# Altium schematic sheet Sheet12.SchDoc(Sheet12)
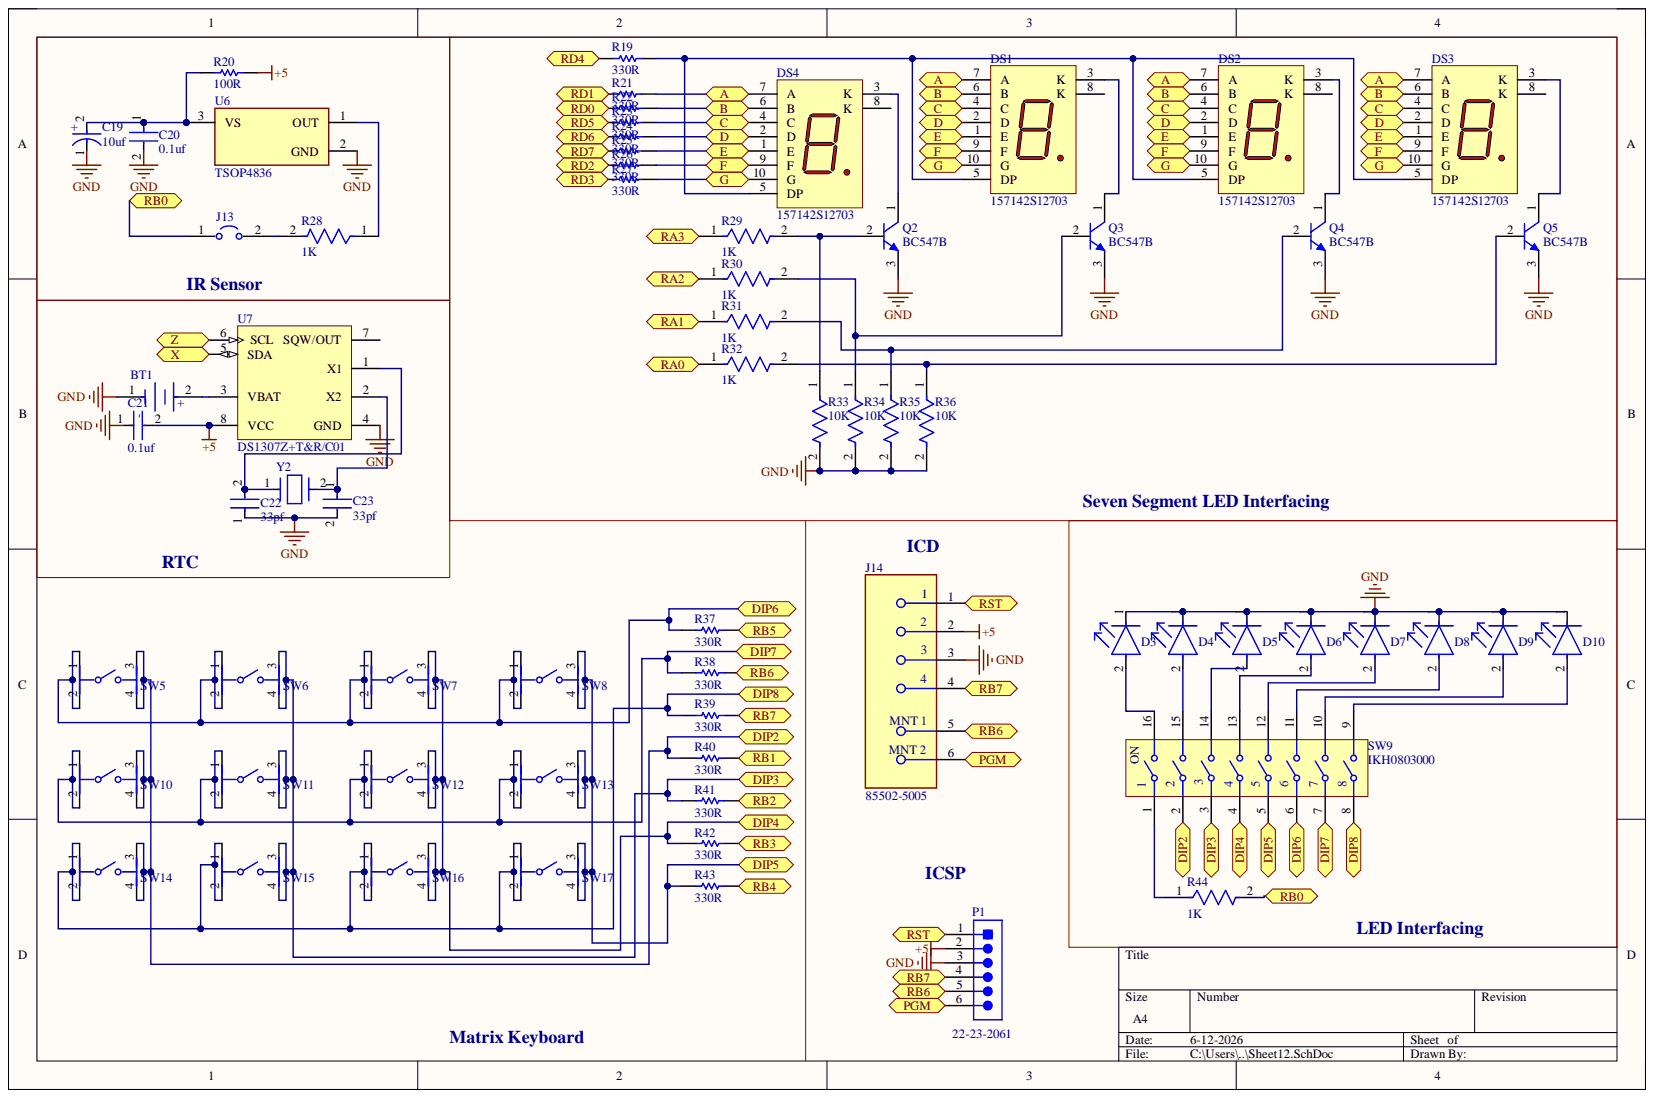
[diagram p0: Sheet12.SchDoc]

## Parts
BT1  3034  [FP-3034-MFG]  (value not drawn)
C19  10uf  [FP-OS-CON-TH-E12-d_0_6-MFG]
C20  0.1uf  [FP-C1206C-EB-MFG]
C21  0.1uf  [FP-C1206C-EB-MFG]
C22  33pf  [FP-RDE1K1-MFG]
C23  33pf  [FP-RDE1K1-MFG]
D3  XLUR12D  [FP-XLUR12D-MFG]  (value not drawn)
D4  XLUR12D  [FP-XLUR12D-MFG]  (value not drawn)
D5  XLUR12D  [FP-XLUR12D-MFG]  (value not drawn)
D6  XLUR12D  [FP-XLUR12D-MFG]  (value not drawn)
D7  XLUR12D  [FP-XLUR12D-MFG]  (value not drawn)
D8  XLUR12D  [FP-XLUR12D-MFG]  (value not drawn)
D9  XLUR12D  [FP-XLUR12D-MFG]  (value not drawn)
D10  XLUR12D  [FP-XLUR12D-MFG]  (value not drawn)
DS1  157142S12703  [WL-T7DS_L19W12.6H7.9]
DS2  157142S12703  [WL-T7DS_L19W12.6H7.9]
DS3  157142S12703  [WL-T7DS_L19W12.6H7.9]
DS4  157142S12703  [WL-T7DS_L19W12.6H7.9]
J13  S1911-46R  [FP-S1911-46R-MFG]  (value not drawn)
J14  85502-5005  [FP-85502-5005-MFG]
P1  22-23-2061  [MOLX-22-23-2061_V]
Q2  BC547B  [ONSC-TO-92-3-29-11]
Q3  BC547B  [ONSC-TO-92-3-29-11]
Q4  BC547B  [ONSC-TO-92-3-29-11]
Q5  BC547B  [ONSC-TO-92-3-29-11]
R19  330R  [RESC3226X70X40ML20T20]
R20  100R  [RESC3116X65X40NL10T20]
R21  330R  [RESC3226X70X40ML20T20]
R22  330R  [RESC3226X70X40ML20T20]
R23  330R  [RESC3226X70X40ML20T20]
R24  330R  [RESC3226X70X40ML20T20]
R25  330R  [RESC3226X70X40ML20T20]
R26  330R  [RESC3226X70X40ML20T20]
R27  330R  [RESC3226X70X40ML20T20]
R28  1K  [FP-RQ732B-IPC_C]
R29  1K  [FP-RQ732B-IPC_C]
R30  1K  [FP-RQ732B-IPC_C]
R31  1K  [FP-RQ732B-IPC_C]
R32  1K  [FP-RQ732B-IPC_C]
R33  10K  [FP-CF18-MFG]
R34  10K  [FP-CF18-MFG]
R35  10K  [FP-CF18-MFG]
R36  10K  [FP-CF18-MFG]
R37  330R  [RESC3226X70X40ML20T20]
R38  330R  [RESC3226X70X40ML20T20]
R39  330R  [RESC3226X70X40ML20T20]
R40  330R  [RESC3226X70X40ML20T20]
R41  330R  [RESC3226X70X40ML20T20]
R42  330R  [RESC3226X70X40ML20T20]
R43  330R  [RESC3226X70X40ML20T20]
R44  1K  [FP-RQ732B-IPC_C]
SW5  TL3305AF260QG  [FP-TL3305AF260QG-MFG]  (value not drawn)
SW6  TL3305AF260QG  [FP-TL3305AF260QG-MFG]  (value not drawn)
SW7  TL3305AF260QG  [FP-TL3305AF260QG-MFG]  (value not drawn)
SW8  TL3305AF260QG  [FP-TL3305AF260QG-MFG]  (value not drawn)
SW9  IKH0803000  [IKH0803000_MFG]
SW10  TL3305AF260QG  [FP-TL3305AF260QG-MFG]  (value not drawn)
SW11  TL3305AF260QG  [FP-TL3305AF260QG-MFG]  (value not drawn)
SW12  TL3305AF260QG  [FP-TL3305AF260QG-MFG]  (value not drawn)
SW13  TL3305AF260QG  [FP-TL3305AF260QG-MFG]  (value not drawn)
SW14  TL3305AF260QG  [FP-TL3305AF260QG-MFG]  (value not drawn)
SW15  TL3305AF260QG  [FP-TL3305AF260QG-MFG]  (value not drawn)
SW16  TL3305AF260QG  [FP-TL3305AF260QG-MFG]  (value not drawn)
SW17  TL3305AF260QG  [FP-TL3305AF260QG-MFG]  (value not drawn)
U6  TSOP4836  [FP-6_550-5169_01-4-MFG]
U7  DS1307Z+T&R/C01  [S8+2_N]
Y2  ECS-80-20-4X  [FP-ECS-80-20-4X-MFG]  (value not drawn)

## Ports
A, B, C, D, DIP2, DIP3, DIP4, DIP5, DIP6, DIP7, DIP8, E, F, G, PGM, RA0, RA1, RA2, RA3, RB0, RB1, RB2, RB3, RB4, RB5, RB6, RB7, RD0, RD1, RD2, RD3, RD4, RD5, RD6, RD7, RST, X, Z

## Nets
+5: C21.2 J14.2 P1.2 R20.1 U7.8(VCC)
GND: BT1.1 C19.1 C20.2 C21.1 C22.1 C23.2 D3.1 D4.1 D5.1 D6.1 D7.1 D8.1 D9.1 D10.1 J14.3 P1.3 Q2.3 Q3.3 Q4.3 Q5.3 R33.2 R34.2 R35.2 R36.2 U6.2 U7.4(GND)
A: DS1.7(A) DS2.7(A) DS3.7(A) DS4.7(A) R21.2 ; port A
B: DS1.6(B) DS2.6(B) DS3.6(B) DS4.6(B) R22.2 ; port B
C: DS1.4(C) DS2.4(C) DS3.4(C) DS4.4(C) R23.2 ; port C
D: DS1.2(D) DS2.2(D) DS3.2(D) DS4.2(D) R24.2 ; port D
DIP2: R40.1 SW5.3 SW5.4 SW10.3 SW10.4 SW14.3 SW14.4 ; port DIP2
DIP3: R41.1 SW6.3 SW6.4 SW11.3 SW11.4 SW15.3 SW15.4 ; port DIP3
DIP4: R42.1 SW7.3 SW7.4 SW12.3 SW12.4 SW16.3 SW16.4 ; port DIP4
DIP5: R43.1 SW8.3 SW8.4 SW13.3 SW13.4 SW17.3 SW17.4 ; port DIP5
DIP6: R37.1 SW5.1 SW5.2 SW6.1 SW6.2 SW7.1 SW7.2 SW8.1 SW8.2 ; port DIP6
DIP7: R38.1 SW10.1 SW10.2 SW11.1 SW11.2 SW12.1 SW12.2 SW13.1 SW13.2 ; port DIP7
DIP8: R39.1 SW14.1 SW14.2 SW15.1 SW15.2 SW16.1 SW16.2 SW17.1 SW17.2 ; port DIP8
E: DS1.1(E) DS2.1(E) DS3.1(E) DS4.1(E) R25.2 ; port E
F: DS1.9(F) DS2.9(F) DS3.9(F) DS4.9(F) R26.2 ; port F
G: DS1.10(G) DS2.10(G) DS3.10(G) DS4.10(G) R27.2 ; port G
PGM: J14.6 P1.6 ; port PGM
RA0: R32.1 ; port RA0
RA1: R31.1 ; port RA1
RA2: R30.1 ; port RA2
RA3: R29.1 ; port RA3
RB0: J13.1 R44.2 ; port RB0
RB1: R40.2 ; port RB1
RB2: R41.2 ; port RB2
RB3: R42.2 ; port RB3
RB4: R43.2 ; port RB4
RB5: R37.2 ; port RB5
RB6: J14.5 P1.5 ; port RB6
RB7: J14.4 P1.4 R39.2 ; port RB7
RST: J14.1 P1.1 ; port RST
X: U7.5(SDA) ; port X
Z: U7.6(SCL) ; port Z
NetBT1_2: BT1.2 U7.3(VBAT)
NetC19_2: C19.2 C20.1 R20.2 U6.3
NetC22_2: C22.2 U7.1(X1) Y2.1
NetC23_1: C23.1 U7.2(X2) Y2.2
NetD3_2: D3.2 SW9.16
NetD4_2: D4.2 SW9.15
NetD5_2: D5.2 SW9.14
NetD6_2: D6.2 SW9.13
NetD7_2: D7.2 SW9.12
NetD8_2: D8.2 SW9.11
NetD9_2: D9.2 SW9.10
NetD10_2: D10.2 SW9.9
NetDS1_3: DS1.3(K) Q3.1
NetDS1_5: DS1.5(DP) DS2.5(DP) DS3.5(DP) DS4.5(DP) R19.2
NetDS2_3: DS2.3(K) Q4.1
NetDS3_3: DS3.3(K) Q5.1
NetDS4_3: DS4.3(K) Q2.1
NetJ13_2: J13.2 R28.2
NetQ2_2: Q2.2 R29.2 R33.1
NetQ3_2: Q3.2 R30.2 R34.1
NetQ4_2: Q4.2 R31.2 R35.1
NetQ5_2: Q5.2 R32.2 R36.1
NetR28_1: R28.1 U6.1
NetR44_1: R44.1 SW9.1
N00001: ; port RD1
N00002: ; port RD0
N00003: ; port RD2
N00004: ; port RD3
N00005: ; port RD5
N00006: ; port RD6
N00007: ; port RD7
N00008: ; port RD4

Not connected: DS1 8(K); DS2 8(K); DS3 8(K); DS4 8(K); R19 1; R21 1; R22 1; R23 1; R24 1; R25 1; R26 1; R27 1; R38 2; SW9 2 3 4 5 6 7 8; U7 7(SQW/OUT)

## Library data of the parts
BT1 = CMP-19636-000006-1: BATTERY RETAINER COIN 20MM SMD; Manufacturer: Keystone Electronics; Number Of Contacts: 2; Automotive: No; Category: Batt; Component Kind: Standard; ComponentLink2Description: Datasheet; ComponentLink2URL: https://www.keyelco.com/product-pdf.cfm?p=798; Contact Material: Nickel; Device Class L1: Connectors; Device Class L2: unset; Device Class L3: unset; Digikey Description: BATTERY RETAINER COIN 20MM SMD; Height: 4.31mm; Lead Free: Yes; Library Name: Altium Content Vault; Manufacturer Part Number: 3034; Mouser Description: Coin Cell Battery Holders COIN CELL RETAINER; Octopart: https://octopart.com/3034-keystone-27554462; Package: 3034; RoHS: TRUE
C19 = CMP-05428-000118-1: Conductive Polymer Aluminum Solid Capacitors (OS-CON) 18uF ±20% 100V RADIAL TH 8x12mm; ESR (Equivalent Series Resistance): 40mR; Capacitance: 18uF; Case/Package: Radial; Component Kind: Standard; Library Name: Altium Content Vault; Max Operating Temperature: 125°C; Min Operating Temperature: -55°C; Mount: Through Hole; Series: OS-CON™, SXE; Tolerance: 20%; Voltage Rating: 100V
C20 C21 = CMP-03020-018297-1: C1206 0.10 μF U2J 20.00% 50 V; Manufacturer: KEMET; Manufacturer Part Number: C1206C104M5JACAUTO; Automotive Grade: Grade 0; Capacitance: 0.10 μF; Material: Ceramic; Temperature Coefficient: 15%; Tolerance: 0.2; Value: 0.10 μF; Voltage Rating: 50 V; Automotive: Yes; Category: Cap; Component Kind: Standard; ComponentLink2Description: Datasheet; ComponentLink2URL: https://content.kemet.com/datasheets/KEM_C1007_X8R_ULTRA_150C_SMD.pdf; Device Class L1: Passive Components; Device Class L2: Capacitors; Device Class L3: Ceramic Capacitors; Height: 0.88mm; Ipc Land Pattern Name: CAPC320160X78; Lead Free: Yes; Library Name: Altium Content Vault; Octopart: https://octopart.com/c1206c104m5jacauto-kemet-84732526; Package: C1206C-EB; RoHS: TRUE; Temperature: -55°C to +150°C; Temperature Characteristic: U2J; Temperature Range High: +150°C; Temperature Range Low: -55°C
C22 C23 = CMP-06035-044214-1: Ceramic Capacitor 33pF ±5% 630V C0G Radial; Component Kind: Standard; Library Name: Altium Content Vault
D3-D10 = CMP-02085-08376351-1: 627nm Red LED; 5x8.6mm, Through-Hole Mount with Red Diffused Round Lamp; Dominant Wavelength: 617nm; Forward Voltage: 1.9V; Luminous Intensity: 39mcd; Test Current: 10mA; Viewing Angle: 30°; Case/Package: Radial; Color: Red; Component Kind: Standard; Height: 8.6mm; Lens Color: Red; Lens Transparency: Diffused; Library Name: Altium Content Vault; Lifecycle Status: Production; Mount: Through Hole; RoHS: Compliant; Wavelength: 627nm
DS1-DS4 = CMP-03913-119894002-2: 7-Seg Display, Comm Cathode, Super Red Rohs Compliant: Yes; Dominant Wavelength: 635nm; Forward Current: 100mA; Forward Voltage: 2V; Luminous Intensity: 20mcd; Max Reverse Voltage (DC): 5V; Component Kind: Standard; Height: 8mm; Length: 19mm; Library Name: Altium Content Vault; Max Operating Temperature: 85°C; Min Operating Temperature: -40°C; RoHS: Compliant; Width: 12.6mm
J13 = CMP-15817-000009-1: JUMPER TIN SMD; Current Rating: 10A; Gender: Non-Gendered; Number of Contacts: 2; Pitch: 0.119inch; Color: Silver; Component Kind: Standard; Contact Material: Brass; Contact Plating: Tin; Depth: 2.51mm; Height: 0.038inch; Length: 6.85mm; Library Name: Altium Content Vault; Material: Brass; Number of Positions: 2; Number of Rows: 1; Orientation: Straight; Package Quantity: 4000; Packaging: Tape and Reel; Plating: Tin; REACH SVHC: No SVHC; RoHS Compliant: Yes; Series: S1; Termination: SMD/SMT
J14 = CMP-04778-000028-1: CONN MOD JACK 4P4C R/A UNSHLD; Connector Type: Socket; Contact Resistance: 20mR; Current Rating: 1.5A; Gender: Female; Insulation Resistance: 500MR; Max Voltage Rating (AC): 125V; Number of Circuits: 2; Number of Contacts: 4; Number of Ports: 1; Pitch: 1.27mm; Shielding: Unshielded; Color: Black; Component Kind: Standard; Contact Material: Brass; Contact Plating: Gold; Depth: 18.1mm; Flammability Rating: UL94 V-0; Halogen Free: Low Halogen; Housing Color: Black; Housing Material: Polymer; Length: 14.13mm; Library Name: Altium Content Vault; Max Operating Temperature: 80°C; Min Operating Temperature: -40°C; Mount: Surface Mount; Number of Positions: 4; Number of Positions Loaded: 4; Orientation: Right Angle; Package Quantity: 1470; Packaging: Tape and Reel; Radiation Hardening: No; RoHS Compliant: Yes; Series: 85502; Termination: SMD/SMT; Voltage Rating (AC): 125V; Width: 11.5mm
P1 = CMP-2000-05536-1: Male Header, Pitch 2.54 mm, 1 x 6 Position, Height 10.67 mm, Tail Length 3.56 mm, 0 to 70 degC, RoHS, Bulk; Brand: Molex; Current Rating: 4A; Mounting Angle: Vertical; Number of Positions: 6; Pitch: 2.54mm; Voltage Rating: 250V; Component Kind: Standard; Contact Material: Brass; Contact Plating: Tin; Datasheet URL: https://datasheet.ciiva.com/19460/232303-19460895.pdf; Datasheet Version: Rev. P, 09/2001; Factory Pack Quantity: 1000; Housing Material: Nylon; Library Name: Altium Content Vault; Manufacturer: Molex; Manufacturer URL: http://www.molex.com/; Mounting Style: ThroughHole; Mounting Technology: ThroughHole; Number of Positions / Contacts: 6; Number of Rows: 1; Package Description: Male Header, Pitch 2.54 mm, 1 x 6 Position, Height 10.67 mm, Tail Length 3.56 mm; Package Reference: 22-23-2061; Packaging: Bulk; Product Category: .100K.K.Connectors; Product Type: Headers; RoHS: Details; Series: 6373; Termination Style: ThroughHole; Tradename: KK; Type: PinStrip
Q2-Q5 = CMP-1048-01467-1: Amplifier Transistor, NPN Silicon, 3-Pin TO-92, Bulk Box; Manufacturer: On Semiconductor; fT Min (MHz): 150; IC Continuous (A): 0.1; Component Kind: Standard; ComponentLink1Description: Manufacturer URL; ComponentLink1URL: http://www.onsemi.com/; ComponentLink2Description: Datasheet; ComponentLink2URL: http://www.onsemi.com/pub_link/Collateral/BC546-D.PDF; ComponentLink3Description: Package Specification; ComponentLink3URL: http://www.onsemi.cn/pub_link/Collateral/2N6426-D.PDF; DatasheetVersion: Rev. 7; Library Name: Altium Content Vault; Mounting Technology: Through Hole; PackageDescription: 3-Pin Transistor Outline, Body 4.825 x 3.685 mm, Pitch 1.27 mm; PackageReference: TO-92-3-29-11_AM_SL; PackageVersion: Rev. AM; Packing: Bulk Box; PartNumber: BC547B; Polarity: NPN; RoHS: FALSE
R19 R21-R27 R37-R43 = CMP-2005-02232-1: Manufacturer: KOA Speer; Part Number: RK73B2ETTE331J; Component Kind: Standard; Library Name: Altium Content Vault
R20 = CMP-2003-04959-1: Manufacturer: Yageo; Part Number: RC1206FR-10100RL; Component Kind: Standard; Library Name: Altium Content Vault
R28-R32 R44 = CMP-03211-006012-1: RQ732B 1206 1KΩ 0.4W 10ppm/°C 0.1% 200V; Manufacturer: TE; Manufacturer Part Number: RQ73C2B1K0BTDF; Automotive Grade: Grade 0; Automotive: Yes; Category: Res; Component Kind: Standard; ComponentLink2Description: Datasheet; ComponentLink2URL: https://www.te.com/commerce/DocumentDelivery/DDEController?Action=showdoc&DocId=Data+Sheet%7F1773270-2%7FA%7Fpdf%7FEnglish%7FENG_DS_1773270-2_A.pdf%7F9-2176388-1149; Composition: Thin Film; Device Class L1: Passive Components; Device Class L2: Resistors; Device Class L3: Chip SMD Resistors; Height: 0.75mm; Ipc Land Pattern Name: RESC310160X60; Lead Free: Yes; Library Name: Altium Content Vault; Octopart: https://octopart.com/rq73c2b1k0btdf-te+connectivity-99292457; Package: RQ732B; Power Rating: 0.4W; Resistance: 1KΩ; RoHS: TRUE; Series: RQ73; Temperature: -55°C to +155°C; Temperature Coefficient: 10ppm/°C; Temperature Range High: +155°C; Temperature Range Low: -55°C; Tolerance: 0.1%; Value: 1KΩ; Voltage Rating: 200V
R33-R36 = CMP-26528-000002-1: RES 10K OHM 1/8W 5% CF AXIAL; Manufacturer: Stackpole Electronics; Manufacturer Part Number: CF18JT10K0; Power Rating: 0.125W; Resistance: 10kΩ; Temperature Coefficient: 0-500ppm/°C; Value: 10kΩ; Voltage Rating: 250V; Automotive: No; Category: Res; Component Kind: Standard; ComponentLink2Description: Datasheet; ComponentLink2URL: http://www.seielect.com/Catalog/SEI-CF_CFM.pdf; Composition: Carbon Film; Device Class L1: Passive Components; Device Class L2: Resistors; Device Class L3: Through-Hole Resistors; Digikey Description: RES 10K OHM 1/8W 5% CF AXIAL; Height: 2mm; Ipc Land Pattern Name: RESAD656W65L360D200; Lead Free: Yes; Library Name: Altium Content Vault; Octopart: https://octopart.com/cf18jt10k0-stackpole+electronics-19205642; Package: CF18; RoHS: TRUE; Series: CF; Temperature: -55°C to +155°C; Temperature Range High: +155°C; Temperature Range Low: -55°C; Tolerance: 5%
SW5-SW8 SW10-SW17 = CMP-17879-000010-1: SWITCH TACTILE SPST-NO 50MA 12V; Actuator Type: Standard; Illumination Type: Non-Illuminated; Mechanical Life: 200000Cycles; Operating Force: 260gf; Switch Function: Off-Mom; Switch Travel: 0.2mm; Throw Configuration: SPST; Component Kind: Standard; Lead Free: Lead Free; Library Name: Altium Content Vault; Max Operating Temperature: 70°C; Min Operating Temperature: -20°C; Mount: Surface Mount; Packaging: Tape and Reel; Termination: Gull Wing
SW9 = CMP-00638-06323270-1: Switch DIP ON OFF SPST 8 Recessed Slide 0.025A 24VDC Gull Wing 1.27mm SMD Tube; Current Rating: 25mA; Electrical Life: 1kcycles; Insulation Resistance: 100MΩ; Number of Positions: 8; Voltage Rating (DC): 50V; Washable: Yes; Component Kind: Standard; ComponentLink1Description: Manufacturer's Website; ComponentLink1URL: http://www.apem.com/; ComponentLink2Description: Datasheet URL; ComponentLink2URL: https://www.apem.com/media/apemProduct/IKH_Series_Dual_in_Line_2018.pdf; Contact Material: Bronze; HelpURL: https://www.apem.com/media/apemProduct/IKH_Series_Dual_in_Line_2018.pdf; Library Name: Altium Content Vault; Max Operating Temperature: 70°C; Min Operating Temperature: -20°C; Mount: Surface Mount; Number of Pins: 8; RoHS: Compliant; Terminal Pitch: 1.27mm; Termination: Solder; Throw Configuration: SPST
U6 = CMP-00030-55401809-1: TSOP4836 TSOP48 Series 5.5 V 36 kHz Through Hole IR Receiver Modules for Remote Control; Frequency: 36kHz; Lead Length: 23.6mm; Max Supply Voltage: 5.5V; Min Supply Voltage: 2.7V; Min Supply Voltage (DC): 2.5V; Nominal Supply Current: 950µA; Operating Supply Current: 700µA; Output Current: 5mA; Power Dissipation: 10mW; Sensing Distance: 45m; Viewing Angle: 45°; Wavelength: 950nm; Case/Package: TSOP; Component Kind: Standard; Contact Plating: Tin; Height: 6.95mm; Lead Free: Lead Free; Length: 6mm; Library Name: Altium Content Vault; Max Operating Temperature: 85°C; Max Supply Voltage (DC): 5.5V; Min Operating Temperature: -25°C; Mount: Through Hole; NexarPartId: 55401809; Number of Pins: 3; Orientation: Side View; Radiation Hardening: No; REACH SVHC: Unknown; RoHS: Compliant; Schedule B: 8541409500; Width: 5.6mm
U7 = CMP-0254-00302-1: 64 x 8, Serial, I2C Real-Time Clock, 5.0V, 8-Pin SO, 0 to 70 degC, Pb-Free, Reel; Code_IPC: SOIC127P600-8; Code_JEDEC: MS-012-AA; Component Kind: Standard; ComponentLink1Description: Manufacturer URL; ComponentLink1URL: http://www.maxim-ic.com; ComponentLink2Description: Datasheet; ComponentLink2URL: http://datasheets.maxim-ic.com/en/ds/DS1307.pdf; ComponentLink3Description: Package Specification; ComponentLink3URL: http://pdfserv.maxim-ic.com/package_dwgs/21-0041.PDF; DatasheetVersion: 10-Feb-2008; Library Name: Altium Content Vault; PackageDescription: 8-Pin Small-Outline IC, Narrow (0.15in), 1.27 mm Pitch, SOIC (N), Pb-Free; PackageReference: S8+2; PackageVersion: revC, 2010
Y2 = CMP-1669-00002-3: NexarPartId: 316504; ESR (Equivalent Series Resistance): 80Ω; Frequency: 8MHz; Frequency Stability: 50ppm; Frequency Tolerance: 0.003%; Load Capacitance: 20pF; Component Kind: Standard; Height: 3.5mm; Lead Free: Lead Free; Length: 11.3538mm; Library Name: Altium Content Vault; Max Operating Temperature: 70°C; Min Operating Temperature: -10°C; Mount: Through Hole; Number of Pins: 49; Packaging: Bulk; RoHS: Compliant; Schedule B: 8541600025, 8541600025; Termination: Radial; Tolerance: 0.003%; Width: 4.65mm
